# Altium schematic sheet [05]_Encoders.SchDoc([05]_Encoders)
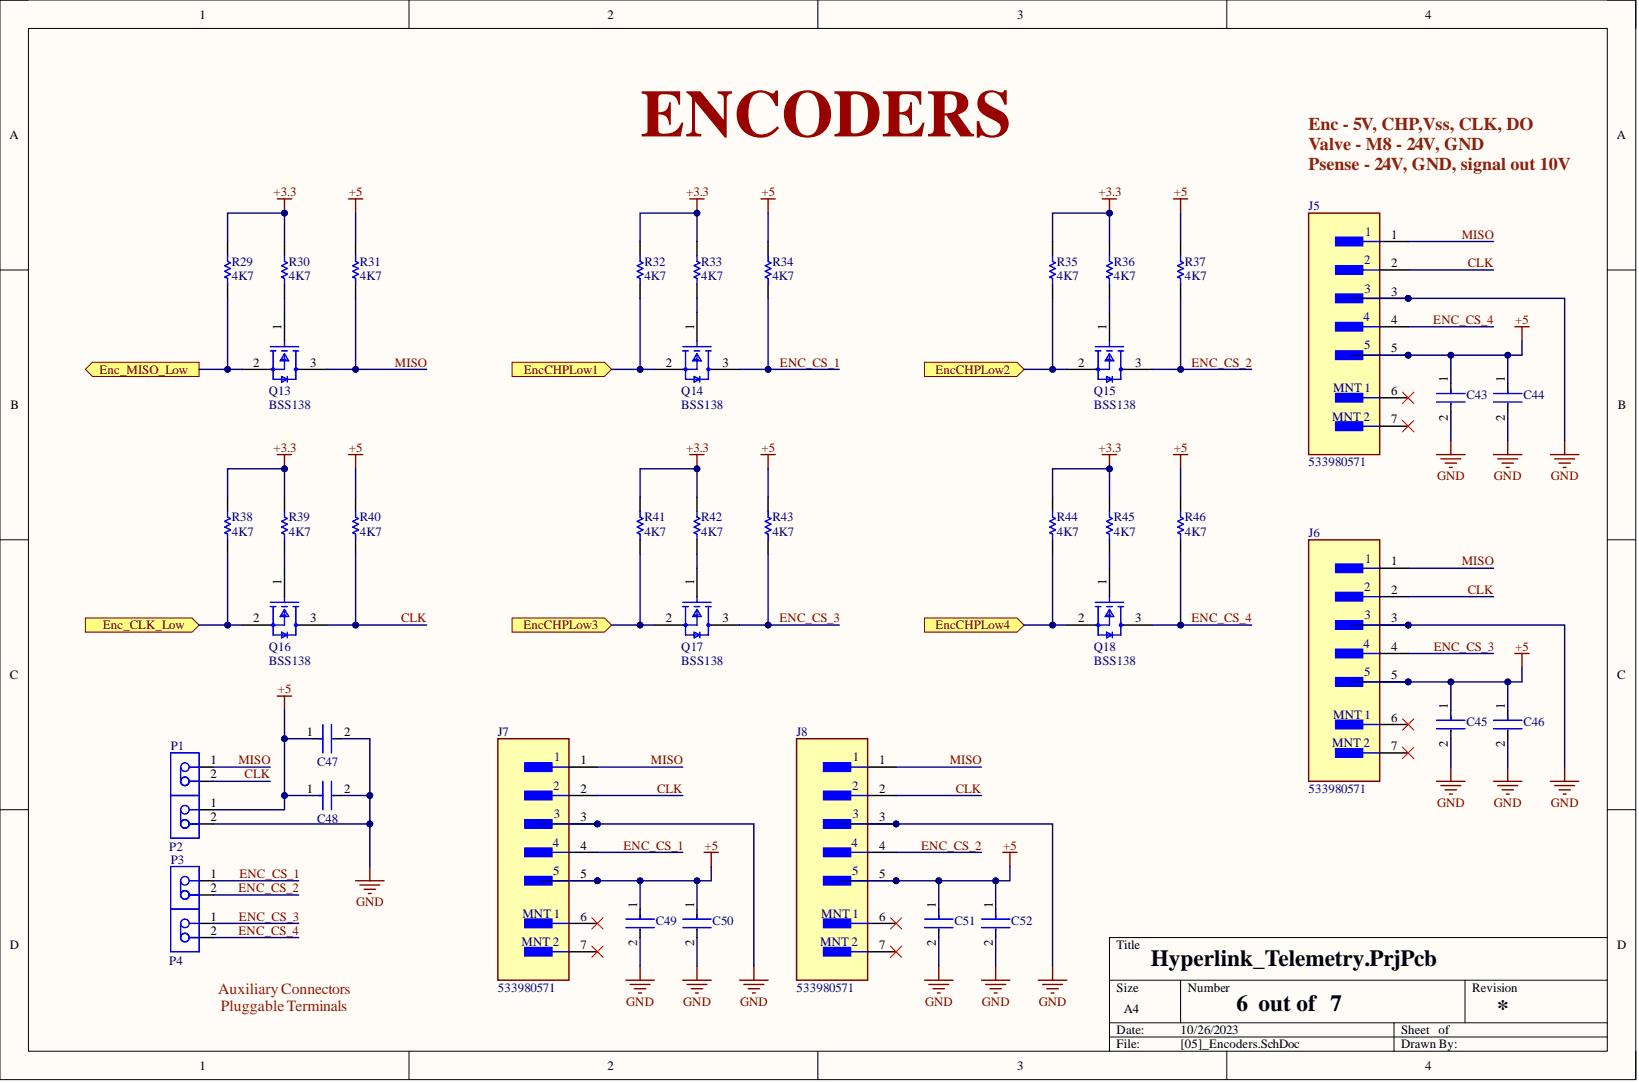
[diagram p0: [05]_Encoders.SchDoc]

## Parts
C43  GRM188R60J476ME15D  (value not drawn)
C44  GRM188R60J476ME15D  (value not drawn)
C45  GRM188R60J476ME15D  (value not drawn)
C46  GRM188R60J476ME15D  (value not drawn)
C47  GRM188R60J476ME15D  (value not drawn)
C48  GRM188R60J476ME15D  (value not drawn)
C49  GRM188R60J476ME15D  (value not drawn)
C50  GRM188R60J476ME15D  (value not drawn)
C51  GRM188R60J476ME15D  (value not drawn)
C52  GRM188R60J476ME15D  (value not drawn)
J5  533980571
J6  533980571
J7  533980571
J8  533980571
P1  1755736  (value not drawn)
P2  1755736  (value not drawn)
P3  1755736  (value not drawn)
P4  1755736  (value not drawn)
Q13  BSS138
Q14  BSS138
Q15  BSS138
Q16  BSS138
Q17  BSS138
Q18  BSS138
R29  4K7
R30  4K7
R31  4K7
R32  4K7
R33  4K7
R34  4K7
R35  4K7
R36  4K7
R37  4K7
R38  4K7
R39  4K7
R40  4K7
R41  4K7
R42  4K7
R43  4K7
R44  4K7
R45  4K7
R46  4K7

## Ports
Enc_CLK_Low, Enc_MISO_Low, EncCHPLow1, EncCHPLow2, EncCHPLow3, EncCHPLow4

## Nets
+3.3: R29.2 R30.2 R32.2 R33.2 R35.2 R36.2 R38.2 R39.2 R41.2 R42.2 R44.2 R45.2
+5: C43.1 C44.1 C45.1 C46.1 C47.1 C48.1 C49.1 C50.1 C51.1 C52.1 J5.5 J6.5 J7.5 J8.5 P2.1 R31.2 R34.2 R37.2 R40.2 R43.2 R46.2
GND: C43.2 C44.2 C45.2 C46.2 C47.2 C48.2 C49.2 C50.2 C51.2 C52.2 J5.3 J6.3 J7.3 J8.3 P2.2
CLK: J5.2 J6.2 J7.2 J8.2 P1.2 Q16.3 R40.1
Enc_CLK_Low: Q16.2 R38.1 ; port Enc_CLK_Low
ENC_CS_1: J7.4 P3.1 Q14.3 R34.1
ENC_CS_2: J8.4 P3.2 Q15.3 R37.1
ENC_CS_3: J6.4 P4.1 Q17.3 R43.1
ENC_CS_4: J5.4 P4.2 Q18.3 R46.1
Enc_MISO_Low: Q13.2 R29.1 ; port Enc_MISO_Low
EncCHPLow1: Q14.2 R32.1 ; port EncCHPLow1
EncCHPLow2: Q15.2 R35.1 ; port EncCHPLow2
EncCHPLow3: Q17.2 R41.1 ; port EncCHPLow3
EncCHPLow4: Q18.2 R44.1 ; port EncCHPLow4
MISO: J5.1 J6.1 J7.1 J8.1 P1.1 Q13.3 R31.1
NetQ13_1: Q13.1 R30.1
NetQ14_1: Q14.1 R33.1
NetQ15_1: Q15.1 R36.1
NetQ16_1: Q16.1 R39.1
NetQ17_1: Q17.1 R42.1
NetQ18_1: Q18.1 R45.1

Not connected: J5 6 7; J6 6 7; J7 6 7; J8 6 7

## Library data of the parts
C43-C52 = CMP-2000-05444-2: Chip Multilayer Ceramic Capacitors for General Purpose, 0603, 47uF, X5R, 15%, 20%, 6.3V; Capacitance: 47uF; Case Code (Imperial): 0603; Case Code (Metric): 1608; Case/Package: 0603; Component Kind: Standard; Dielectric: X5R; JLC: C1623; Length: 0.063inch; Library Name: Altium Content Vault; Max Operating Temperature: 85°C; Min Operating Temperature: -55°C; Mount: Surface Mount; Packaging: Tape and Reel; Thickness: 0.039inch; Tolerance: 20%; Voltage Rating: 6.3V; Voltage Rating (DC): 6.3V; Width: 0.031inch
J5-J8 = CMP-2000-05250-2: CONN HEADER SMD 5POS 1.25MM; Manufacturer: Molex; Conn Gender: Male; Conn Orientation: Vertical; Current Rating: 1A; Number Of Contacts: 5; Standoff Height: 0.05mm; Voltage Rating: 125V; Automotive: No; Category: Conn; Component Kind: Standard; ComponentLink2Description: Datasheet; ComponentLink2URL: https://www.molex.com/webdocs/datasheets/pdf/en-us/0533980571_PCB_HEADERS.pdf; Contact Material: Tin,Nickel; Device Class L1: Connectors; Device Class L2: Headers and Wire Housings; Device Class L3: unset; Digikey Description: CONN HEADER SMD 5POS 1.25MM; Footprint Url: https://www.molex.com/pdm_docs/sd/533980571_sd.pdf; Height: 4.9mm; Ipc Land Pattern Name: HDRV5W64P254_1X5_2032X64X457; JLC: C504986; Lead Free: Yes; Library Name: Altium Content Vault; Manufacturer Part Number: 533980571; Mouser Description: Wire-To-Board Connector, Vertical, PicoBlade 53398 Series, Surface Mount, Header, 5, 1.25 mm; Number Of Rows: 1; Octopart: https://octopart.com/53398-0571-molex-655292; Package: CONN_HDR5; Pitch: 1.25mm; RoHS: TRUE; Temperature: -40°C to +105°C; Temperature Range High: +105°C; Temperature Range Low: -40°C
P1-P4 = CMP-2000-05300-1: Valve Connector, Pluggable Terminal 5.08 pitch; Manufacturer: Phoenix Contact; Content: 250pc(s); Industrial packaging: Yes; Number of contacts: 2; Pitch: 5.08mm; Colour: Green; Component Kind: Standard; Datasheet URL: http://www.mouser.com/ds/2/324/ItemDetail_1755736-921028.pdf; Datasheet Version: Apr-16; JLC: C8461; Library Name: Altium Content Vault; Manufacturer part No.: 1755736; Manufacturer URL: http://www.phoenixcontact.com/; Type: MSTBVA2,5/2-G-5,08
Q13-Q18 = CMP-07173-000101-1: MOSFET N-CH 50V 220MA SOT-23; Drain to Source Resistance: 3.5R; Element Configuration: Single; Fall Time: 9ns; Gate to Source Voltage (Vgs): 20V; Input Capacitance: 27pF; Max Power Dissipation: 360mW; Nominal Vgs: 1.3V; Number of Elements: 1; On-State Resistance: 6R; Turn-Off Delay Time: 20ns; Turn-On Delay Time: 2.5ns; Case/Package: SOT-23; Component Kind: Standard; Contact Plating: Tin; Continuous Drain Current (ID): 220mA; Current Rating: 220mA; Drain to Source Breakdown Voltage: 50V; Drain to Source Voltage (Vdss): 50V; Dual Supply Voltage: 50V; Height: 0.93mm; JLC: C8545; Lead Free: Lead Free; Length: 2.92mm; Library Name: Altium Content Vault; Max Operating Temperature: 150°C; Min Operating Temperature: -55°C; Mount: Surface Mount; Number of Pins: 3; Package Quantity: 1; Packaging: Tape and Reel; Power Dissipation: 360mW; Radiation Hardening: No; Rds On Max: 3.5R; REACH SVHC: No SVHC; Rise Time: 9ns; Termination: SMD/SMT; Threshold Voltage: 1.3V; Voltage Rating (DC): 50V; Weight: 0.03g; Width: 1.3mm
R29-R46 = CMP-2001-00550-5: Chip Resistor, 4.7 KOhm, +/- 1%, 0.125 W, -55 to 155 degC, 0805 (2012 Metric), RoHS, Tape and Reel; Manufacturer: Panasonic; Voltage Rating: 150 V; Component Kind: Standard; ComponentLink1Description: Manufacturer URL; ComponentLink1URL: http://www.panasonic.com/; ComponentLink2Description: Datasheet; ComponentLink2URL: http://industrial.panasonic.com/cdbs/www-data/pdf/RDA0000/AOA0000C86.pdf; DatasheetVersion: 04/2015; Height: 0.6 mm; JLC: C17673; Length: 2 mm; Library Name: Altium Content Vault; Max Operating Temperature: 155 degC; Min Operating Temperature: -55 degC; Mount: Surface Mount; Mounting Technology: Surface Mount; Package Quantity: 5000; PackageDescription: 2-Pin Surface Mount Device, Body 2 x 1.25 mm; PackageReference: 0805; Packaging: Tape and Reel; Pins: 2; REACH SVHC: Yes; RoHSCompliant: true
